# Altium schematic sheet bq76PL455_isolator.SchDoc(bq76PL455_isolator)
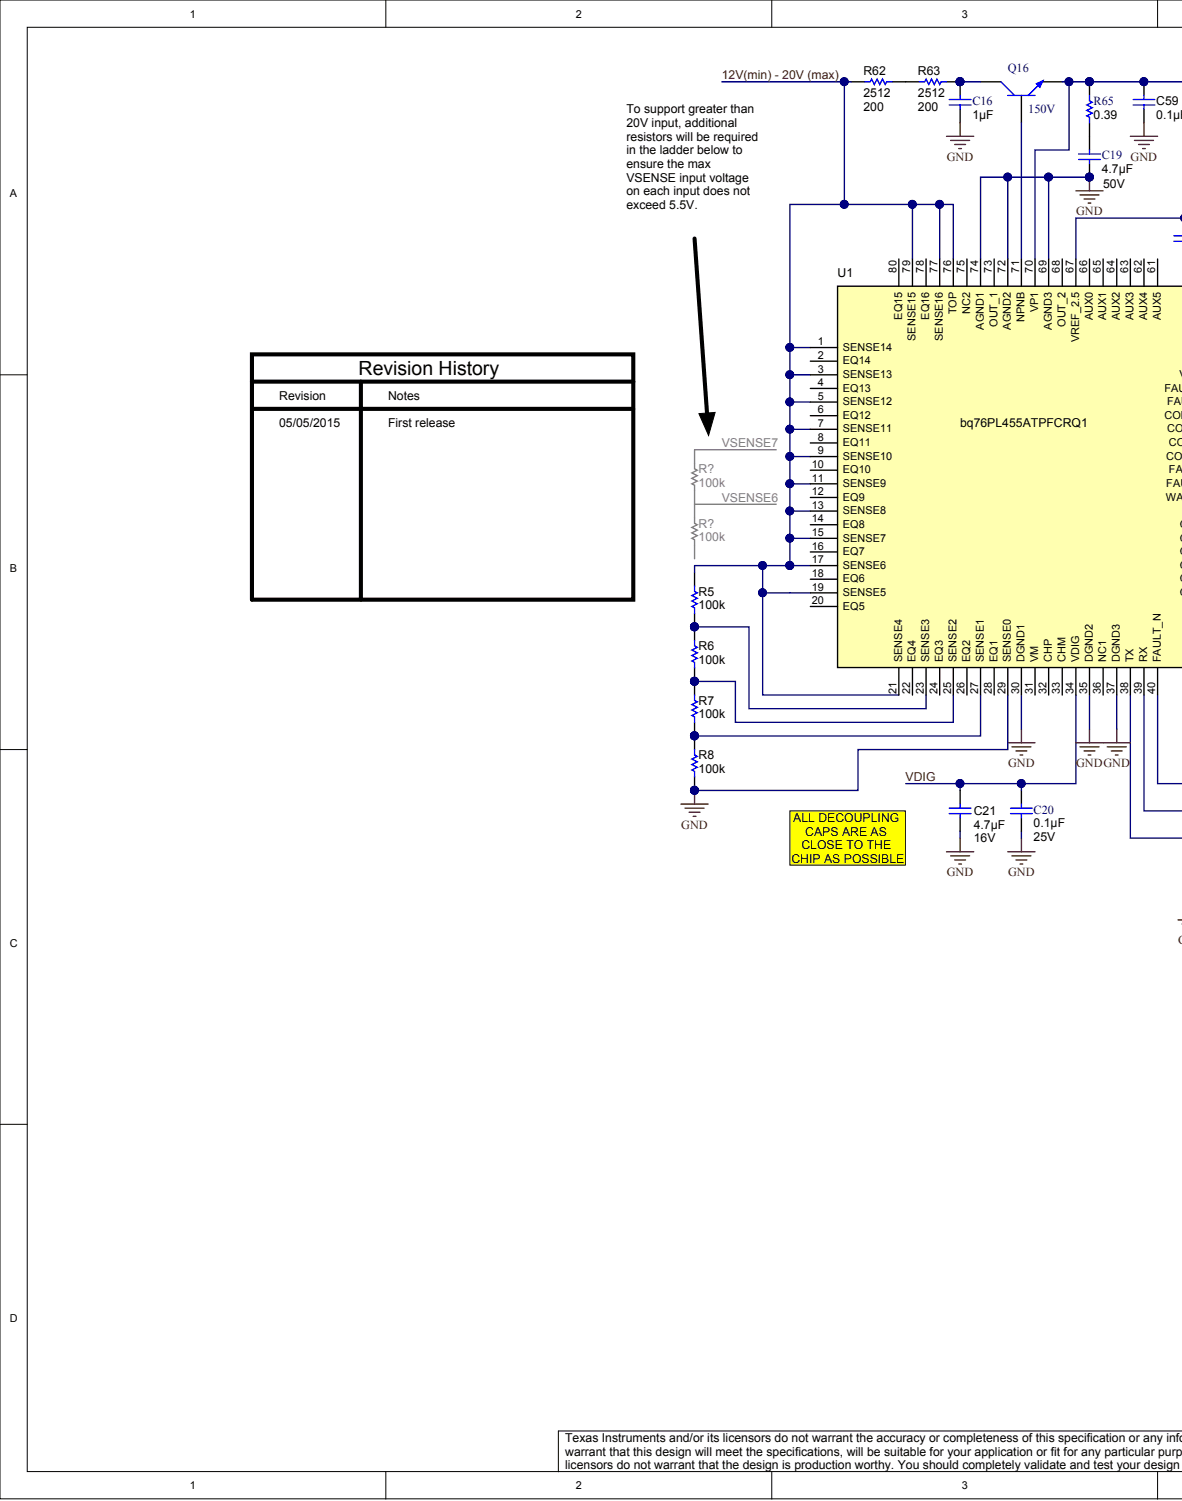
[diagram p0: bq76PL455_isolator.SchDoc, left]
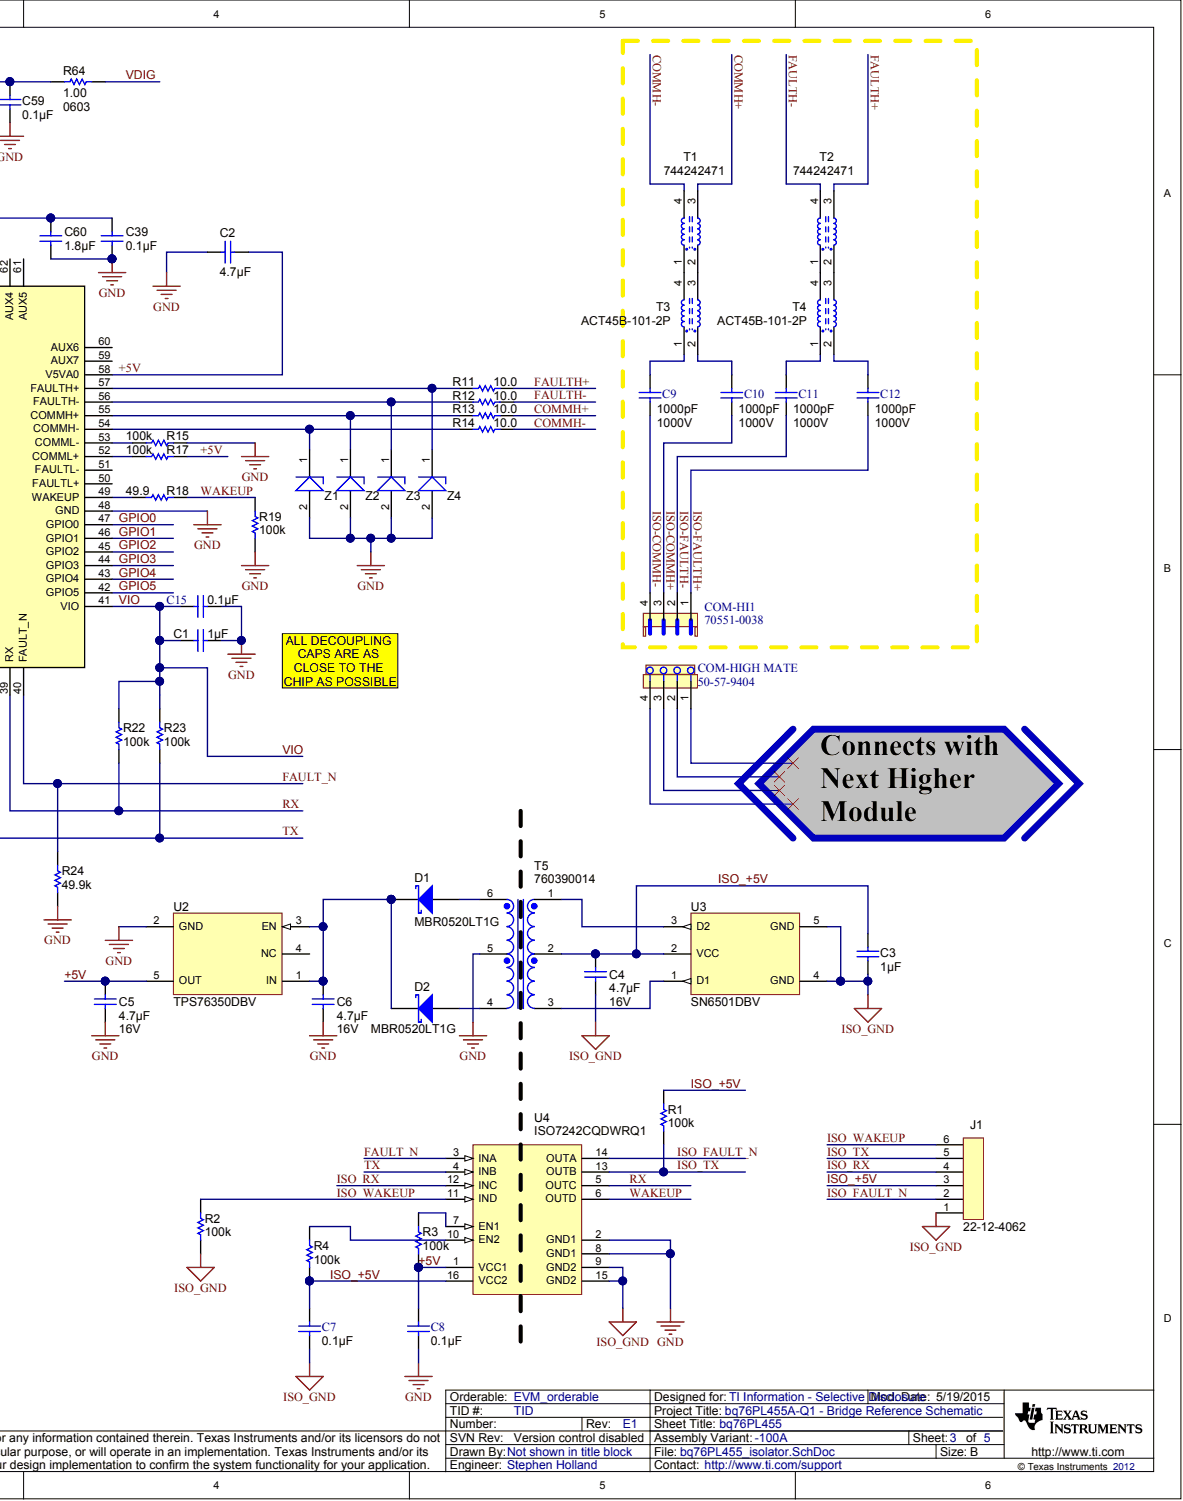
[diagram p1: bq76PL455_isolator.SchDoc, right]

## Parts
C1  ValueDisplayed: 1µF  [0603L]  (hidden comment: GRM188R71C105KA12D)
C2  ValueDisplayed: 4.7µF  [0805_HV]  (hidden comment: GRM21BR71C475KA73L)
C3  ValueDisplayed: 1µF  [0603L]  (hidden comment: GRM188R71C105KA12D)
C4  Value2: 16V  ValueDisplayed: 4.7µF  [0805_HV]  (hidden comment: GRM21BR71C475KA73L)
C5  Value2: 16V  ValueDisplayed: 4.7µF  [0805_HV]  (hidden comment: GRM21BR71C475KA73L)
C6  Value2: 16V  ValueDisplayed: 4.7µF  [0805_HV]  (hidden comment: GRM21BR71C475KA73L)
C7  ValueDisplayed: 0.1µF  [0603L]  (hidden comment: C0603X104K3RACTU)
C8  ValueDisplayed: 0.1µF  [0603L]  (hidden comment: C0603X104K3RACTU)
C9  value 1000pF  Value2: 1000V  [1206L]  (hidden comment: CC1206KKX7RCBB102)
C10  value 1000pF  Value2: 1000V  [1206L]  (hidden comment: CC1206KKX7RCBB102)
C11  value 1000pF  Value2: 1000V  [1206L]  (hidden comment: CC1206KKX7RCBB102)
C12  value 1000pF  Value2: 1000V  [1206L]  (hidden comment: CC1206KKX7RCBB102)
C15  ValueDisplayed: 0.1µF  [0603L]  (hidden comment: C0603X104K3RACTU)
C16  ValueDisplayed: 1µF  [1206]  (hidden comment: GRM31CR72A105KA01L)
C19  Value2: 50V  ValueDisplayed: 4.7µF  [1206]  (hidden comment: GRM31CR71H475KA12L)
C20  Value2: 25V  ValueDisplayed: 0.1µF  [0603L]  (hidden comment: C0603X104K3RACTU)
C21  Value2: 16V  ValueDisplayed: 4.7µF  [0805_HV]  (hidden comment: GRM21BR71C475KA73L)
C39  ValueDisplayed: 0.1µF  [0603]  (hidden comment: C0603X104K3RACTU)
C59  ValueDisplayed: 0.1µF  [0603L]  (hidden comment: C0603X104K3RACTU)
C60  ValueDisplayed: 1.8µF  [1210]  (hidden comment: C1210C185K3RACTU)
COM-HI1  70551-0038  [70551-0038]
D1  MBR0520LT1G  [SOD-123]
D2  MBR0520LT1G  [SOD-123]
J1  22-12-4062  [22-12-4062]
Q16  Vce: 150V  [DPAK]  (hidden comment: ZXTN4004KTC)
R1  value 100k  [0603L]  (hidden comment: RC0603FR-07100KL)
R2  value 100k  [0603L]  (hidden comment: RC0603FR-07100KL)
R3  value 100k  [0603L]  (hidden comment: RC0603FR-07100KL)
R4  value 100k  [0603L]  (hidden comment: RC0603FR-07100KL)
R5  value 100k  [0603]  (hidden comment: CRCW0603100KJNEA)
R6  value 100k  [0603]  (hidden comment: CRCW0603100KJNEA)
R7  value 100k  [0603]  (hidden comment: CRCW0603100KJNEA)
R8  value 100k  [0603]  (hidden comment: CRCW0603100KJNEA)
R11  value 10.0  [1206]  (hidden comment: CRCW120610R0FKEA)
R12  value 10.0  [1206]  (hidden comment: CRCW120610R0FKEA)
R13  value 10.0  [1206]  (hidden comment: CRCW120610R0FKEA)
R14  value 10.0  [1206]  (hidden comment: CRCW120610R0FKEA)
R15  value 100k  [0603L]  (hidden comment: RC0603FR-07100KL)
R17  value 100k  [0603L]  (hidden comment: RC0603FR-07100KL)
R18  value 49.9  Res_ohm: 49.9  [0603]  (hidden comment: CRCW060349R9FKEA)
R19  value 100k  [0603L]  (hidden comment: RC0603FR-07100KL)
R22  value 100k  [0603L]  (hidden comment: RC0603FR-07100KL)
R23  value 100k  [0603L]  (hidden comment: RC0603FR-07100KL)
R24  value 49.9k  [0603]  (hidden comment: RC0603FR-0749K9L)
R62  value 200  PackageReference: 2512  [2512M]  (hidden comment: CRCW2512200RJNEG)
R63  value 200  PackageReference: 2512  [2512M]  (hidden comment: CRCW2512200RJNEG)
R64  value 1.00  PackageReference: 0603  [0603L]  (hidden comment: RC0603FR-071RL)
R65  value 0.39  Res_ohm: 0.39  [0603]  (hidden comment: ERJ-3RQFR39V)
R?  value 100k  [0603]  (hidden comment: CRCW0603100KJNEA)
T1  744242471  [744242471]
T2  744242471  [744242471]
T3  ACT45B-101-2P  [ACT-45B-xxx-2P]
T4  ACT45B-101-2P  [ACT-45B-xxx-2P]
T5  760390014  [WURTH_760390014]
U1  bq76PL455ATPFCRQ1  [PFC0080A_L]
U2  TPS76350DBV  [DBV0005A_N]
U3  SN6501DBV  [DBV0005A_N]
U4  ISO7242CQDWRQ1  [DW0016A_N]
Z1  PESD5V0U1UA,115  [SOD123_SOD323_dual]  (value not drawn)
Z2  PESD5V0U1UA,115  [SOD123_SOD323_dual]  (value not drawn)
Z3  PESD5V0U1UA,115  [SOD123_SOD323_dual]  (value not drawn)
Z4  PESD5V0U1UA,115  [SOD123_SOD323_dual]  (value not drawn)

## Nets
GND: C1.2 C2.1 C5.2 C6.2 C8.2 C15.1 C16.2 C19.2 C20.2 C21.2 C39.2 C59.1 C60.2 R8.1 R15.2 R19.2 R24.2 T5.5 U1.29(SENSE0) U1.30(DGND1) U1.35(DGND2) U1.37(DGND3) U1.48(GND) U1.69(AGND3) U1.72(AGND2) U1.74(AGND1) U2.2(GND) U4.2(GND1) U4.8(GND1) Z1.2 Z2.2 Z3.2 Z4.2
ISO_GND: C3.1 C4.2 C7.2 J1.1 R2.2 U3.4(GND) U3.5(GND) U4.9(GND2) U4.15(GND2)
12V(MIN) - 20V (MAX): R5.2 R62.1 U1.1(SENSE14) U1.3(SENSE13) U1.5(SENSE12) U1.7(SENSE11) U1.9(SENSE10) U1.11(SENSE9) U1.13(SENSE8) U1.15(SENSE7) U1.17(SENSE6) U1.19(SENSE5) U1.21(SENSE4) U1.76(TOP) U1.77(SENSE16) U1.79(SENSE15)
+5V: C2.2 C5.1 C8.1 R3.2 R17.2 U1.58(V5VA0) U2.5(OUT) U4.1(VCC1)
COMMH+: R13.2 T1.3
COMMH-: R14.2 T1.4
FAULT_N: R24.1 U1.40(FAULT_N) U4.3(INA)
FAULTH+: R11.2 T2.3
FAULTH-: R12.2 T2.4
GPIO0: U1.47(GPIO0)
GPIO1: U1.46(GPIO1)
GPIO2: U1.45(GPIO2)
GPIO3: U1.44(GPIO3)
GPIO4: U1.43(GPIO4)
GPIO5: U1.42(GPIO5)
ISO-COMMH+: C10.2 COM-HI1.3
ISO-COMMH-: C9.1 COM-HI1.4
ISO-FAULTH+: C12.1 COM-HI1.1
ISO-FAULTH-: C11.2 COM-HI1.2
ISO_+5V: C3.2 C4.1 C7.1 J1.3 R1.1 R4.2 T5.2 U3.2(VCC) U4.16(VCC2)
ISO_FAULT_N: J1.2 U4.14(OUTA)
ISO_RX: J1.4 U4.12(INC)
ISO_TX: J1.5 R1.2 U4.13(OUTB)
ISO_WAKEUP: J1.6 R2.1 U4.11(IND)
RX: R22.2 U1.39(RX) U4.5(OUTC)
TX: R23.2 U1.38(TX) U4.4(INB)
VDIG: C20.1 C21.1 R64.1 U1.34(VDIG)
VIO: C1.1 C15.2 R22.1 R23.1 U1.41(VIO)
WAKEUP: R18.2 R19.1 U4.6(OUTD)
NetC6_1: C6.1 D1.1 D2.1 U2.1(IN) U2.3(EN)
NetC9_2: C9.2 T3.1
NetC10_1: C10.1 T3.2
NetC11_1: C11.1 T4.1
NetC12_2: C12.2 T4.2
NetC16_1: C16.1 Q16.4 R63.1
NetC19_1: C19.1 R65.2
NetC39_1: C39.1 C60.1 U1.67(VREF_2.5)
NetC59_2: C59.2 Q16.3 R64.2 R65.1 U1.70(VP1)
NetD1_2: D1.2 T5.6
NetD2_2: D2.2 T5.4
NetQ16_1: Q16.1 U1.71(NPNB)
NetR3_1: R3.1 U4.7(EN1)
NetR4_1: R4.1 U4.10(EN2)
NetR5_1: R5.1 R6.2 U1.23(SENSE3)
NetR6_1: R6.1 R7.2 U1.25(SENSE2)
NetR7_1: R7.1 R8.2 U1.27(SENSE1)
NetR11_1: R11.1 U1.57(FAULTH+) Z4.1
NetR12_1: R12.1 U1.56(FAULTH-) Z3.1
NetR13_1: R13.1 U1.55(COMMH+) Z2.1
NetR14_1: R14.1 U1.54(COMMH-) Z1.1
NetR15_1: R15.1 U1.53(COMML-)
NetR17_1: R17.1 U1.52(COMML+)
NetR18_1: R18.1 U1.49(WAKEUP)
NetR62_2: R62.2 R63.2
NetT1_1: T1.1 T3.4
NetT1_2: T1.2 T3.3
NetT2_1: T2.1 T4.4
NetT2_2: T2.2 T4.3
NetT5_1: T5.1 U3.3(D2)
NetT5_3: T5.3 U3.1(D1)
N00025:
N00026:
N00027:
N00028:
N00029:

Not connected: R? 1 2; U1 2(EQ14) 4(EQ13) 6(EQ12) 8(EQ11) 10(EQ10) 12(EQ9) 14(EQ8) 16(EQ7) 18(EQ6) 20(EQ5) 22(EQ4) 24(EQ3) 26(EQ2) 28(EQ1) 31(VM) 32(CHP) 33(CHM) 36(NC1) 50(FAULTL+) 51(FAULTL-) 59(AUX7) 60(AUX6) 61(AUX5) 62(AUX4) 63(AUX3) 64(AUX2) 65(AUX1) 66(AUX0) 68(OUT_2) 73(OUT_1) 75(NC2) 78(EQ16) 80(EQ15); U2 4(NC)

## Library data of the parts
C1 C3 = GRM188R71C105KA12D: CAP, CERM, 1uF, 16V, +/-10%, X7R, 0603; Manufacturer: MuRata; Cap_Case: 0603; Cap_F: 0.000001; CompType: Capacitor; ESR_Ohm: 0.011; Polarized: N; TaMax_C: 125; TaMin_C: -55; Tolerance_%: 10; U/M: EA; Value1: 0.011 ohm; Alternate Manufacturer: -; Alternate PartNumber: -; Component Kind: Standard; ComponentLink1Description: Datasheet link; ComponentLink1URL: http://search.murata.co.jp/Ceramy/PnsearchViewAction.do?HnOFG=ON&HnNFG=ON&SEL_CNT=50&sLang=en&sKey=GRM188R71C105KA12D&x=9&y=9&search=startwith; LatestRevisionDate: 2011-09-19; LatestRevisionNote: Removed DigiKeyPartNumber parameter.; Library Name: Capacitor_Ceramic.IntLib; MountType: SMT; PackageReference: 0603; PartNumber: GRM188R71C105KA12D; Published: 2008-06-19; RoHS: Y; Signal Integrity: Cap; Simulation: Cap; Supplier 1: Digi-Key; Supplier 2: Arrow; Supplier Part Number 1: 490-3900-1-ND; Supplier Part Number 2: GRM188R71C105KA12D; SymbolVersion: 2008-07-09; Technology: Ceramic; TempCo: X7R; Value: 1uF; Value2: 16V; VDC_V: 16V; Publisher: National Semiconductor
C2 C4-C6 C21 = GRM21BR71C475KA73L: CAP, CERM, 4.7uF, 16V, +/-10%, X7R, 0805; Manufacturer: MuRata; Cap_Case: 0805; Cap_F: 0.0000047; CompType: Capacitor; ESR_Ohm: 0.006; Polarized: N; TaMax_C: 125; TaMin_C: -55; Tolerance_%: 10; U/M: EA; Value1: 0.006 ohm; Alternate Manufacturer: -; Alternate PartNumber: -; Component Kind: Standard; ComponentLink1Description: Datasheet link; ComponentLink1URL: http://search.murata.co.jp/Ceramy/PnsearchViewAction.do?HnOFG=ON&HnNFG=ON&SEL_CNT=50&sLang=en&sKey=GRM21BR71C475KA73L&x=9&y=9&search=startwith; LatestRevisionDate: 2011-09-19; LatestRevisionNote: Removed DigiKeyPartNumber parameter.; Library Name: Capacitor_Ceramic.IntLib; MountType: SMT; PackageReference: 0805; PartNumber: GRM21BR71C475KA73L; Published: 2008-06-19; RoHS: Y; Signal Integrity: Cap; Simulation: Cap; Supplier 1: Digi-Key; Supplier 2: Arrow; Supplier Part Number 1: 490-4522-1-ND; Supplier Part Number 2: GRM21BR71C475KA73L; SymbolVersion: 2008-07-09; Technology: Ceramic; TempCo: X7R; Value: 4.7uF; VDC_V: 16V; Publisher: National Semiconductor
  C2: Value2: 16V
C7 C8 C15 C20 = C0603X104K3RACTU: CAP, CERM, 0.1uF, 25V, +/-10%, X7R, 0603; Manufacturer: Kemet; Cap_Case: 0603; Cap_F: 0.0000001; CompType: Capacitor; Polarized: N; TaMax_C: 125; TaMin_C: -55; Tolerance_%: 10; U/M: EA; VDC_V: 25; Component Kind: Standard; ComponentLink1Description: Datasheet link; ComponentLink1URL: http://www.kemet.com/kemet/web/homepage/kechome.nsf/vapubfiles/F3102_Y5V.pdf/$file/F3102_Y5V.pdf; Dielectric: X7R; DigiKeyPartNumber: 399-5345-1-ND; LatestRevisionDate: 2010-08-25; LatestRevisionNote: Enhanced Description field.; Library Name: Capacitor_Ceramic.IntLib; MountType: SMT; PackageReference: 0603; PartNumber: C0603X104K3RACTU; Published: 2008-06-19; RoHS: Y; Signal Integrity: Cap; Simulation: Cap; SymbolVersion: 2008-07-09; Technology: Ceramic; Value: 0.1uF; Value1: ?; Publisher: National Semiconductor
  C7 C8 C15: Value2: 25V
C9-C12 = CC1206KKX7RCBB102: CAP, CERM, 1000pF, 1000V, +/-10%, X7R, 1206; Manufacturer: Yageo America; Cap_Case: 1206; Cap_F: 0.000000001; CompType: Capacitor; Polarized: N; TaMax_C: 125; TaMin_C: -55; Tolerance_%: 10; U/M: EA; VDC_V: 1000; Alternate Manufacturer: -; Alternate PartNumber: -; Component Kind: Standard; ComponentLink1Description: Datasheet link; ComponentLink1URL: http://www.yageo.com/pdf/X7R_16-to-500V_9.pdf; LatestRevisionDate: 2011-09-19; LatestRevisionNote: Removed DigiKeyPartNumber parameter.; Library Name: Capacitor_Ceramic.IntLib; MountType: SMT; PackageReference: 1206; PartNumber: CC1206KKX7RCBB102; Published: 2008-06-19; RoHS: Y; Signal Integrity: Cap; Simulation: Cap; Supplier 1: Digi-Key; Supplier Part Number 1: 311-1144-1-ND; SymbolVersion: 2008-07-09; Technology: Ceramic; TempCo: X7R; Value1: ?; ValueDisplayed: 1000pF; Publisher: National Semiconductor
C16 = GRM31CR72A105KA01L: CAP, CERM, 1uF, 100V, +/-10%, X7R, 1206; Manufacturer: MuRata; Cap_Case: 2220; Cap_F: 0.000001; CompType: Capacitor; Polarized: N; TaMax_C: 125; TaMin_C: -55; Tolerance_%: 10; U/M: EA; VDC_V: 100; Alternate Manufacturer: -; Alternate PartNumber: -; Component Kind: Standard; ComponentLink1Description: Datasheet link; ComponentLink1URL: http://search.murata.co.jp/Ceramy/PnsearchViewAction.do?HnOFG=ON&HnNFG=ON&SEL_CNT=50&sLang=en&sKey=GRM31CR72A105KA01L&x=9&y=9&search=startwith; LatestRevisionDate: 2011-09-19; LatestRevisionNote: Removed DigiKeyPartNumber parameter.; Library Name: Capacitor_Ceramic.IntLib; MountType: SMT; PackageReference: 1206; PartNumber: GRM31CR72A105KA01L; Published: 2008-06-19; RoHS: Y; Signal Integrity: Cap; Simulation: Cap; Supplier 1: Digi-Key; Supplier 2: Arrow; Supplier Part Number 1: 490-3909-1-ND; Supplier Part Number 2: GRM31CR72A105KA01L; SymbolVersion: 2008-07-09; Technology: Ceramic; TempCo: X7R; Value: 1uF; Value1: ?; Value2: 100V; Publisher: National Semiconductor
C19 = GRM31CR71H475KA12L: CAP, CERM, 4.7uF, 50V, +/-10%, X7R, 1206; Manufacturer: MuRata; Cap_Case: 2220; Cap_F: 0.0000047; CompType: Capacitor; Polarized: N; TaMax_C: 125; TaMin_C: -55; Tolerance_%: 10; U/M: EA; VDC_V: 50; Alternate Manufacturer: -; Alternate PartNumber: -; Component Kind: Standard; ComponentLink1Description: Datasheet link; ComponentLink1URL: http://search.murata.co.jp/Ceramy/PnsearchViewAction.do?HnOFG=ON&HnNFG=ON&SEL_CNT=50&sLang=en&sKey=GRM31CR71H475KA12L&x=9&y=9&search=startwith; LatestRevisionDate: 2011-09-19; LatestRevisionNote: Removed DigiKeyPartNumber parameter.; Library Name: Capacitor_Ceramic.IntLib; MountType: SMT; PackageReference: 1206; PartNumber: GRM31CR71H475KA12L; Published: 2008-06-19; RoHS: Y; Signal Integrity: Cap; Simulation: Cap; Supplier 1: Farnell; Supplier 2: Newark; Supplier 3: Arrow; Supplier Part Number 1: 1735545; Supplier Part Number 2: 24R6347; Supplier Part Number 3: GRM31CR71H475KA12L; SymbolVersion: 2008-07-09; Technology: Ceramic; TempCo: X7R; Value: 4.7uF; Value1: ?; Publisher: National Semiconductor
C39 = C0603X104K3RACTU: CAP, CERM, 0.1uF, 25V, +10/%, X7R, 0603; Manufacturer: Kemet; Cap_F: 0.0000001; CompType: Capacitor; Polarized: N; TaMax_C: 125; TaMin_C: -55; Tolerance_%: 10; U/M: EA; Value1: Unknown; VDC_V: 25; Cap_Case: 0603; Component Kind: Standard; ComponentLink1Description: Datasheet link; ComponentLink1URL: http://www.kemet.com/kemet/web/homepage/kechome.nsf/vapubfiles/F3102_Y5V.pdf/$file/F3102_Y5V.pdf; LatestRevisionDate: 2013-01-11; LatestRevisionNote: Changed publisher; Library Name: Capacitor_Ceramic.IntLib; MountType: SMT; PackageReference: 0603; PartNumber: C0603X104K3RACTU; Published: 2008-06-19; RoHS: Y; Signal Integrity: Cap; Simulation: Cap; Supplier 1: Digi-Key; Supplier 2: Mouser; Supplier 3: Farnell; Supplier Part Number 1: 399-5345-1-ND; Supplier Part Number 2: 80-C0603X104K3R; Supplier Part Number 3: 1601773; SymbolVersion: 2008-07-09; Technology: Ceramic; TempCo: X7R; Value: 0.1uF; Publisher: Texas Instruments
C59 = C0603X104K3RACTU: CAP, CERM, 0.1uF, 25V, +/-10%, X7R, 0603; Manufacturer: Kemet; Cap_Case: 0603; Cap_F: 0.0000001; CompType: Capacitor; Polarized: N; TaMax_C: 125; TaMin_C: -55; Tolerance_%: 10; U/M: EA; Value1: Unknown; VDC_V: 25; Component Kind: Standard; ComponentLink1Description: Datasheet link; ComponentLink1URL: http://www.kemet.com/kemet/web/homepage/kechome.nsf/vapubfiles/F3102_Y5V.pdf/$file/F3102_Y5V.pdf; LatestRevisionDate: 2013-06-14; Library Name: Capacitor_Ceramic.IntLib; MountType: SMT; PackageReference: 0603; PartNumber: C0603X104K3RACTU; Published: 2008-06-19; RoHS: Y; Signal Integrity: Cap; Simulation: Cap; Supplier 1: Digi-Key; Supplier 2: Mouser; Supplier 3: Farnell; Supplier Part Number 1: 399-5345-1-ND; Supplier Part Number 2: 80-C0603X104K3R; Supplier Part Number 3: 1601773; SymbolVersion: 2008-07-09; Technology: Ceramic; TempCo: X7R; Value: 0.1uF; Value2: 25V; Publisher: Texas Instruments
C60 = C1210C185K3RACTU: CAP, CERM, 1.8uF, 25V, +/-10%, X7R, 1210; Manufacturer: Kemet; CompType: Capacitor; Polarized: N; TaMax_C: 125; TaMin_C: -55; Tolerance_%: 10; U/M: EA; Alternate Manufacturer: -; Alternate PartNumber: -; Cap_Case: 1210; Component Kind: Standard; ComponentLink1Description: Datasheet link; ComponentLink1URL: http://capacitoredge.kemet.com/capedge2/DataSheet?pn=C1210C185K3RACTU; LatestRevisionDate: 2012-08-23; LatestRevisionNote: Changed publisher; Library Name: Capacitor_Ceramic_1-8uF-25V.SchLib; MountType: SMT; PackageReference: 1210; PartNumber: C1210C185K3RACTU; Published: 2008-06-19; RoHS: Y; Signal Integrity: Cap; Simulation: Cap; Supplier 1: Digi-Key; Supplier 2: Newark; Supplier Part Number 1: 399-3082-1-ND; SymbolVersion: 2008-07-09; Technology: Ceramic; TempCo: X7R; Value: 1.8uF; Value1: ?; VDC_V: 25V; Publisher: Texas Instruments
COM-HI1 = 70551-0038: Manufacturer: Molex; CompType: Connector; SymbolCreator: Use LDAP id; SymbolVersion: use current date i.e. 2008-05-20; U/M: EA, IN, or GM; Alternate Manufacturer: -; Alternate PartNumber: -; Component Kind: Standard; ComponentLink1Description: Datasheet Link; ComponentLink1URL: http://www.molex.com/pdm_docs/sd/705510038_sd.pdf; Library Name: 70551-0038.SchLib; MountType: TH; PackageReference: 70551-0038; PartNumber: 70551-0038; RoHS: Y
D1 D2 = MBR0520LT1G: Diode, Schottky, 20V, 0.5A, SOD-123; Manufacturer: ON Semiconductor; Io_A: 0.5; RJC_CperW: 150; TJmax_C: 125; U/M: EA; Value2: 0.385V; Vf_V: 0.385; Vr_V: 20; Component Kind: Standard; ComponentLink1Description: Datasheet link; ComponentLink1URL: http://www.onsemi.com/pub_link/Collateral/MBR0520LT1-D.PDF; CompType: Diode; LatestRevisionDate: 2014-01-24; Library Name: Diode.IntLib; MountType: SMT; PackageReference: SOD-123; PartNumber: MBR0520LT1G; Published: 2008-06-26; RoHS: Y; Signal Integrity: Diode; Simulation: mbr0520lt1; Supplier 1: Digi-Key; Supplier 2: Mouser; Supplier 3: Farnell; Supplier Part Number 1: MBR0520LT1GOSCT-ND; Supplier Part Number 2: 863-MBR0520LT1G; Supplier Part Number 3: 9556915; SymbolVersion: 2008-12-08; Type: Schottky; Value: 20V; Value1: 0.5A; Publisher: Texas Instruments
J1 = 22-12-4062: Manufacturer: Molex; CompType: Connector; SymbolCreator: Use LDAP id; SymbolVersion: use current date i.e. 2008-05-20; U/M: EA; Alternate Manufacturer: -; Alternate PartNumber: -; Component Kind: Standard; ComponentLink1Description: Datasheet Link; ComponentLink1URL: http://www.molex.com/pdm_docs/sd/022124062_sd.pdf; Library Name: 22-12-4062.SchLib; MountType: TH; PackageReference: 22-12-4062; PartNumber: 22-12-4062; RoHS: Y; Supplier 1: Digi-Key; Supplier 2: Mouser; Supplier Part Number 1: 0022124062-ND; Supplier Part Number 2: 538-22-12-4062
Q16 = ZXTN4004KTC: TRANS 150V 1A NPN LED DRVR TO252; Manufacturer: Diodes/Zetex; CompType: NPN Transistor; SymbolCreator: Use LDAP id; SymbolVersion: use current date i.e. 2008-05-20; U/M: EA, IN, or GM; Alternate Manufacturer: -; Alternate PartNumber: -; Component Kind: Standard; ComponentLink1Description: Datasheet Link; ComponentLink1URL: http://www.diodes.com/datasheets/ZXTN4004K.pdf; Library Name: ZXTN4004K.SchLib; MountType: SMT; PackageReference: DPAK; PartNumber: ZXTN4004KTC; RoHS: Y
R1-R4 R15 R17 R19 R22 R23 = RC0603FR-07100KL: RES, 100k ohm, 1%, 0.1W, 0603; Manufacturer: Yageo America; CompType: Resistor; EIA_Code: E96; Power_W: 0.1; Res_ohm: 100000; Tolerance_%: 1; U/M: EA; VDC_V: 150; Component Kind: Standard; ComponentLink1Description: Datasheet link; ComponentLink1URL: http://www.yageo.com/pdf/Pu-RC0603_51_PbFree_L_2.pdf; DigiKeyPartNumber: 311-100KHRCT-ND; LatestRevisionDate: 2010-09-01; LatestRevisionNote: Enhanced Description field.; Library Name: Resistor_0603.IntLib; MountType: SMT; PackageReference: 0603; PartNumber: RC0603FR-07100KL; Published: 2008-06-26; RoHS: Y; Signal Integrity: Resistor; Simulation: Resistor; SymbolVersion: 2009-05-29; Technology: Thick Film; Value1: 1%; Value2: 0.1W; Value_Code: 100k; Publisher: National Semiconductor
R5-R8 R? = CRCW0603100KJNEA: RES, 100 k, 5%, 0.1 W, 0603; Manufacturer: Vishay-Dale; CompType: Resistor; EIA_Code: E24; Power_W: 0.1; Res_ohm: 100000; TempCo: 200 ppm/C; Tolerance_%: 5; U/M: EA; Value2: 0.1W; VDC_V: 75; Component Kind: Standard; ComponentLink1Description: Datasheet link; ComponentLink1URL: http://www.vishay.com/docs/20035/dcrcwe3.pdf; LatestRevisionDate: 2014-12-16; Library Name: Resistor_0603.IntLib; MountType: SMT; PackageReference: 0603; PartNumber: CRCW0603100KJNEA; Published: 2008-06-26; RoHS: Y; Signal Integrity: Resistor; Simulation: Resistor; Supplier 1: Digi-Key; Supplier 2: Mouser; Supplier 3: Farnell; Supplier Part Number 1: 541-100KGCT-ND; Supplier Part Number 2: 71-CRCW0603J-100K-E3; Supplier Part Number 3: 1692517; SymbolVersion: 2009-05-29; Technology: Thick Film; Value1: 5%; Value_Code: 100k; ValueDisplayed: 100k; Publisher: Texas Instruments
R11-R14 = CRCW120610R0FKEA: RES, 10.0 ohm, 1%, 0.25W, 1206; Manufacturer: Vishay-Dale; CompType: Resistor; EIA_Code: E96; Power_W: 0.25; Res_ohm: 10; TempCo: 100 ppm/C; Tolerance_%: 1; U/M: EA; Value_Code: 10R0; VDC_V: 200; Component Kind: Standard; ComponentLink1Description: Datasheet link; ComponentLink1URL: http://www.vishay.com/docs/20035/dcrcwe3.pdf; LatestRevisionDate: 2013-07-11; Library Name: Resistor_1206.IntLib; MountType: SMT; PackageReference: 1206; PartNumber: CRCW120610R0FKEA; Published: 2008-06-26; RoHS: Y; Signal Integrity: Resistor; Simulation: Resistor; Supplier 1: Digi-Key; Supplier 2: Mouser; Supplier 3: Farnell; Supplier Part Number 1: 541-10.0FCT-ND; Supplier Part Number 2: 71-CRCW1206-10-E3; Supplier Part Number 3: 1469974; SymbolVersion: 2009-05-29; Technology: Thick Film; Value1: 1%; Value2: 0.25W; Publisher: Texas Instruments
R18 = CRCW060349R9FKEA: RES, 49.9 ohm, 1%, 0.1W, 0603; Manufacturer: Vishay-Dale; CompType: Resistor; EIA_Code: E96; Power_W: 0.1; TempCo: 100 ppm/C; Tolerance_%: 1; U/M: EA; Value_Code: 49R9; VDC_V: 75; Alternate Manufacturer: -; Alternate PartNumber: -; Component Kind: Standard; ComponentLink1Description: Datasheet link; ComponentLink1URL: http://www.vishay.com/docs/20035/dcrcwe3.pdf; LatestRevisionDate: 2012-04-20; LatestRevisionNote: Changed publisher; Library Name: Resistor_0603.IntLib; MountType: SMT; PackageReference: 0603; PartNumber: CRCW060349R9FKEA; Published: 2008-06-26; RoHS: Y; Signal Integrity: Resistor; Simulation: Resistor; Supplier 1: Digi-Key; Supplier 2: Farnell; Supplier 3: Newark; Supplier Part Number 1: 541-49.9HCT-ND; Supplier Part Number 2: 1152041; Supplier Part Number 3: 52K8566; SymbolVersion: 2009-05-29; Technology: Thick Film; Value1: 1%; Value2: 0.1W; Publisher: Texas Instruments
R24 = RC0603FR-0749K9L: RES, 49.9k ohm, 1%, 0.1W, 0603; Manufacturer: Yageo America; CompType: Resistor; EIA_Code: E96; Power_W: 0.1; Res_ohm: 49900; TempCo: 200 ppm/C; Tolerance_%: 1; U/M: EA; Value_Code: 49k9; VDC_V: 150; Component Kind: Standard; ComponentLink1Description: Datasheet link; ComponentLink1URL: http://www.yageo.com/pdf/Pu-RC0603_51_PbFree_L_2.pdf; LatestRevisionDate: 2013-01-08; Library Name: Resistor_0603.IntLib; MountType: SMT; PackageReference: 0603; PartNumber: RC0603FR-0749K9L; Published: 2008-06-26; RoHS: Y; Signal Integrity: Resistor; Simulation: Resistor; Supplier 1: Digi-Key; Supplier 2: Mouser; Supplier 3: Farnell; Supplier Part Number 1: 311-49.9KHRCT-ND; Supplier Part Number 2: 603-RC0603FR-0749K9L; Supplier Part Number 3: 1799347; SymbolVersion: 2009-05-29; Technology: Thick Film; Value1: 1%; Value2: 0.1W; Publisher: Texas Instruments
R62 = CRCW2512200RJNEG: RES 200 OHM 1W 5% 2512 SMD; Manufacturer: Vishay/Dale; CompType: Resistor; SymbolCreator: Use LDAP id; SymbolVersion: use current date i.e. 2008-05-20; U/M: EA, IN, or GM; Alternate Manufacturer: -; Alternate PartNumber: -; Component Kind: Standard; ComponentLink1Description: Datasheet Link; ComponentLink1URL: http://www.vishay.com/docs/20035/dcrcwe3.pdf; Library Name: CRCW2512200RJNEG.SchLib; MountType: SMT; PartNumber: CRCW2512200RJNEG; RoHS: Y
R63 = CRCW2512200RJNEG: RES 200 OHM 1W 5% 2512 SMD; Manufacturer: Vishay/Dale; CompType: Resistor; SymbolCreator: Use LDAP id; SymbolVersion: use current date i.e. 2008-05-20; U/M: EA, IN, or GM; Value: 200; Alternate Manufacturer: -; Alternate PartNumber: -; Component Kind: Standard; ComponentLink1Description: Datasheet Link; ComponentLink1URL: http://www.vishay.com/docs/20035/dcrcwe3.pdf; Library Name: CRCW2512200RJNEG.SchLib; MountType: SMT; PartNumber: CRCW2512200RJNEG; RoHS: Y
R64 = RC0603FR-071RL: RES, 1.00 ohm, 1%, 0.1W, 0603; Manufacturer: Yageo America; CompType: Resistor; EIA_Code: E96; Power_W: 0.1; Res_ohm: 1; U/M: EA; Value_Code: 1R00; VDC_V: 150; Component Kind: Standard; ComponentLink1Description: Datasheet link; ComponentLink1URL: http://www.yageo.com/pdf/Pu-RC0603_51_PbFree_L_2.pdf; DigiKeyPartNumber: 311-1.00HRCT-ND; LatestRevisionDate: 2010-09-01; LatestRevisionNote: Enhanced Description field.; Library Name: Resistor_0603.IntLib; MountType: SMT; PartNumber: RC0603FR-071RL; Published: 2008-06-26; RoHS: Y; Signal Integrity: Resistor; Simulation: Resistor; SymbolVersion: 2009-05-29; Technology: Thick Film; Tolerance_%: 1; Value1: 1%; Value2: 0.1W; Publisher: National Semiconductor
R65 = ERJ-3RQFR39V: RES, 0.39 ohm, 1%, 0.1W, 0603; Manufacturer: Panasonic; CompType: Resistor; EIA_Code: E96; Power_W: 0.1; TempCo: 300 ppm/C; Tolerance_%: 1; U/M: EA; Value_Code: R390; Alternate Manufacturer: -; Alternate PartNumber: -; Component Kind: Standard; ComponentLink1Description: Datasheet link; ComponentLink1URL: http://www.panasonic.com/industrial/components/pdf/AOA0000CE3.pdf; LatestRevisionDate: 2011-09-19; LatestRevisionNote: Removed DigiKeyPartNumber parameter.; Library Name: Resistor_0603.IntLib; MountType: SMT; PackageReference: 0603; PartNumber: ERJ-3RQFR39V; Published: 2008-06-26; RoHS: Y; Signal Integrity: Resistor; Simulation: Resistor; Supplier 1: Digi-Key; Supplier 2: Arrow; Supplier Part Number 1: P.39AJCT-ND; Supplier Part Number 2: ERJ-3RQFR39V; SymbolVersion: 2009-05-29; Technology: Thick Film; Value1: 1%; Value2: 0.1W; Publisher: National Semiconductor
T1 T2 = 744242471: CHOKE COMMON MODE 2200 OHM .4A; Manufacturer: Wurth; CompType: Common Mode Choke; SymbolCreator: Use LDAP id; SymbolVersion: use current date i.e. 2008-05-20; U/M: EA, IN, or GM; Alternate Manufacturer: -; Alternate PartNumber: -; Component Kind: Standard; ComponentLink1Description: Datasheet Link; ComponentLink1URL: http://katalog.we-online.de/pbs/datasheet/744242471.pdf; Library Name: Wurth 744242471.Schlib; MountType: SMT; PackageReference: 744242xxx; PartNumber: 744242471; RoHS: Used in BOM report.  Use Y or N.; Supplier 1: Digi-Key; Supplier 2: Mouser; Supplier Part Number 1: 732-1686-2-ND; Supplier Part Number 2: 710-744242471
T3 T4 = ACT45B-101-2P: CHOKE COMMON MODE 5800 OHM .15A; Manufacturer: TDK; CompType: Common Mode Choke; SymbolCreator: Use LDAP id; SymbolVersion: use current date i.e. 2008-05-20; U/M: EA, IN, or GM; Alternate Manufacturer: -; Alternate PartNumber: -; Component Kind: Standard; ComponentLink1Description: Datasheet Link; ComponentLink1URL: http://www.tdk.co.jp/tefe02/e9711_act.pdf; Library Name: ACT45B-1-1-2P.SchLib; MountType: SMT; PackageReference: ACT45B; PartNumber: ACT45B-101-2P; RoHS: Used in BOM report.  Use Y or N.
T5 = 760390014: Transformer, 475uH, SMD; Manufacturer: Wurth Elektronik eiSos; DCR_Ohm: 0.37; Imax_A: 150mA; LeakageL: TBD; SymbolCreator: a0412868; U/M: EA; Component Kind: Standard; ComponentLink1Description: Datasheet Link; CompType: Transformer; Ind_H: 475uH; LatestRevisionDate: 2014-01-24; Library Name: 760390014.Schlib; MountType: TH; PackageReference: 6.73x10.05x4.19mm; PartNumber: 760390014; RoHS: R; Supplier 1: Digi-Key; Supplier 2: Mouser; Supplier Part Number 1: 732-4458-2-ND; Supplier Part Number 2: 710-760390014; SymbolVersion: 2014-02-19; Value: 475uH; Publisher: Texas Instruments
U1 = bq76PL455ATPFCRQ1 (alternate symbol): 16 Cell Battery Monitor with Passive Cell Balancing,  PFC0080A; Manufacturer: Texas Instruments; CompType: IC; SymbolCreator: x0412868; Component Kind: Standard; ComponentLink1Description: Datasheet Link; ComponentLink1URL: http://www.ti.com/lit/pdf/SLUSC51; Library Name: bq76PL455A-Q1.SchLib; MountType: SMT; PackageReference: PFC0080A; PartNumber: bq76PL455ATPFCRQ1; RoHS: Y; SymbolVersion: 2015-04-23
U2 = TPS76350DBV: LOW-POWER 150-mA LOW-DROPOUT LINEAR REGULATOR, DBV0005A; Alternate Manufacturer: None; Manufacturer: Texas Instruments; CompType: IC; SymbolCreator: a0411947; U/M: EA; Component Kind: Standard; ComponentLink1Description: Datasheet Link; ComponentLink1URL: http://www.ti.com/lit/ds/symlink/tps76301.pdf; LatestRevisionDate: 2014-01-27; Library Name: TI_Pre_Release.IntLib; MountType: SMT; PackageReference: DBV0005A; PartNumber: TPS76350DBV; RoHS: Y; SymbolVersion: 2013-07-26; Publisher: Texas Instruments
U3 = SN6501DBV: Transformer Driver for Isolated Power Supplies, DBV0005A; Alternate Manufacturer: None; Manufacturer: Texas Instruments; CompType: IC; SymbolCreator: a0411947; U/M: EA; Component Kind: Standard; ComponentLink1Description: Datasheet Link; ComponentLink1URL: http://www.ti.com/lit/ds/symlink/sn6501.pdf; LatestRevisionDate: 2014-01-27; Library Name: TI_Pre_Release.IntLib; MountType: SMT; PackageReference: DBV0005A; PartNumber: SN6501DBV; RoHS: Y; SymbolVersion: 2013-06-28; Publisher: Texas Instruments
U4 = ISO7242CQDWRQ1: 25 Mbps Automotive Catalog Quad Channels, 2 / 2, Digital Isolator, -40 to +125 degC, 16-pin SOIC (DW), Green (RoHS & no Sb/Br); Alternate Manufacturer: None; Alternate PartNumber: Equivalent; Manufacturer: Texas Instruments; Datarate(Mbps): 25; Input Noise Filter: Yes; Insulation Rating(VRMS): 2500; Prop Delay(Max)(ns): 42; Supply Voltage(s)(V): 3.3, 5; TTL/CMOS Input Threshold: TTL; Channel Configuration: 02/02/2012; Code_JEDEC: MS-013-AA; Component Kind: Standard; ComponentLink1Description: Datasheet; ComponentLink1URL: http://www.ti.com/general/docs/lit/getliterature.tsp?genericPartNumber=ISO7242C-Q1&fileType=pdf; ComponentLink2Description: URL Link1; ComponentLink2URL: SamplesURL:http://www.ti.com/product/iso7242c-q1#samples; DatasheetVersion: SLLSE40A; Eco-Plan: Green (RoHS & no Sb/Br); Library Name: TI Interface Isolator.IntLib; MountType: SMT; PackageDescription: 16-Pin Small Outline Integrated Circuit, 10.3 x 7.5 mm, Pitch 1.27 mm Pitch; PackageReference: DW0016A; PackageVersion: revG, Jan-2011; PartNumber: ISO7242CQDWRQ1; Rating: Automotive; SubFamily: Isolator; Supplier 1: Digi-Key; Supplier 2: Newark; Supplier 3: Mouser; Supplier Part Number 1: ISO7242CQDWRQ1-ND; Supplier Part Number 2: 70T7538; Supplier Part Number 3: 595-ISO7242CQDWRQ1; Thermal Shutdown: No
Z1-Z4 = PESD5V0U1UA,115: DIODE ESD PROT UNI 5V SOD323-2; Manufacturer: NXP; CompType: TVS ESD diode; SymbolCreator: Use LDAP id; SymbolVersion: use current date i.e. 2008-05-20; U/M: EA; Alternate Manufacturer: -; Alternate PartNumber: -; Component Kind: Standard; ComponentLink1Description: Datasheet Link; ComponentLink1URL: http://www.nxp.com/documents/data_sheet/PESD5V0U1UA_UB_UL.pdf; Library Name: PESD5V0U1UA_115.SchLib; MountType: SMT; PackageReference: SOD-323; PartNumber: PESD5V0U1UA,115; RoHS: Y
